# Altium schematic sheet ALimb.SchDoc(ALimb)
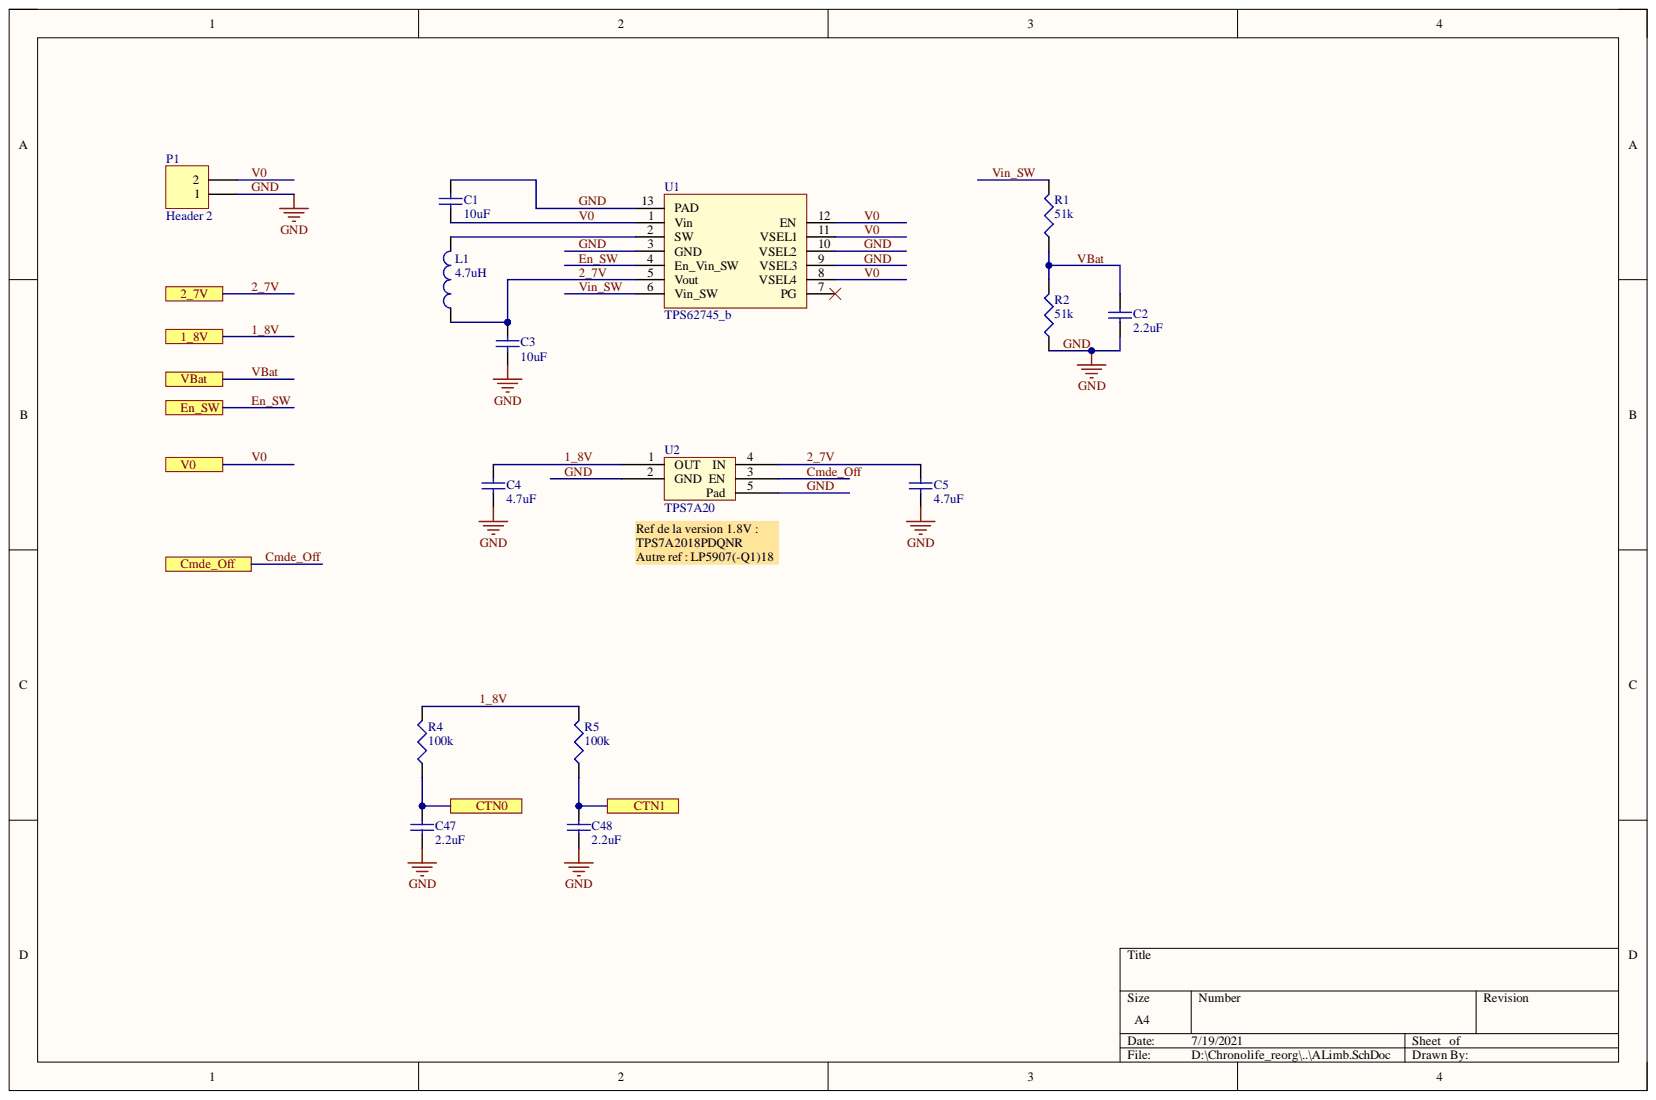
[diagram p0: ALimb.SchDoc]

## Parts
C1  10uF  [0603_GC]
C2  2.2uF  [0402_GC]
C3  10uF  [0603_GC]
C4  4.7uF  [0402_GC]
C5  4.7uF  [0402_GC]
C47  2.2uF  [0402_GC]
C48  2.2uF  [0402_GC]
L1  4.7uH  [SELF_252012]
P1  Header 2  [2SIP150PAD_G]
R1  51k  [0402_GC]
R2  51k  [0402_GC]
R4  100k  [0402_GC]
R5  100k  [0402_GC]
U1  TPS62745_b  [TPS62740]
U2  TPS7A20  [X2SON]

## Ports
1_8V, 2_7V, Cmde_Off, CTN0, CTN1, En_SW, V0, VBat

## Nets
1_8V: C4.2 R4.2 R5.2 U2.1(OUT) ; port 1_8V
2_7V: C3.1 C5.2 L1.1 U1.5(Vout) U2.4(IN) ; port 2_7V
Cmde_Off: U2.3(EN) ; port Cmde_Off
CTN0: C47.2 R4.1 ; port CTN0
CTN1: C48.2 R5.1 ; port CTN1
En_SW: U1.4(En_Vin_SW) ; port En_SW
GND: C1.1 C2.1 C3.2 C4.1 C5.1 C47.1 C48.1 P1.1 R2.1 U1.3(GND) U1.9(VSEL3) U1.10(VSEL2) U1.13(PAD) U2.2(GND) U2.5(Pad)
V0: C1.2 P1.2 U1.1(Vin) U1.8(VSEL4) U1.11(VSEL1) U1.12(EN) ; port V0
VBat: C2.2 R1.1 R2.2 ; port VBat
Vin_SW: R1.2 U1.6(Vin_SW)
NetL1_2: L1.2 U1.2(SW)

Not connected: U1 7(PG)

## Library data of the parts
C1-C5 C47 C48 = Cap: Capacitor; Component Kind: Standard; LatestRevisionDate: 17-Jul-2002; LatestRevisionNote: Re-released for DXP Platform.; Library Name: Miscellaneous Devices.IntLib; PackageDescription: Radial Cap, Thru-Hole; 2 Leads; 0.3 in Pin Spacing; PackageReference: RAD-0.3; Published: 8-Jun-2000; Signal Integrity: Cap; Simulation: CAP; Publisher: Altium Limited
  C1 C3: Value: 10uF
  C2 C47 C48: Value: 2.2uF
  C4 C5: Value: 4.7uF
L1 = Inductor: Code_IEC: 1005; Code_JEITA: 0402; Component Kind: Standard; Description: Inductor; LatestRevisionDate: 29-May-2009; LatestRevisionNote: IPC-7351 Footprint Added.; Library Name: Miscellaneous Devices.IntLib; PackageDescription: Chip Inductor; PackageReference: 0402-A; Published: 8-Jun-2000; Signal Integrity: Inductor; Simulation: INDUCTOR; Value: 4.7uH; Publisher: Altium Limited
P1 = Header 2: Header, 2-Pin; Component Kind: Standard; LatestRevisionDate: 17-Jul-2002; LatestRevisionNote: Re-released for DXP Platform.; Library Name: Miscellaneous Connectors.IntLib; Signal Integrity: Connector; Publisher: Altium Limited
R1 R2 R4 R5 = Res3: Resistor; Component Kind: Standard; LatestRevisionDate: 29-May-2009; LatestRevisionNote: IPC-7351 Footprint Added.; Library Name: Miscellaneous Devices.IntLib; PackageDescription: Chip Resistor; PackageReference: J1-0603; Published: 8-Jun-2000; Signal Integrity: Res; Simulation: RESISTOR; Publisher: Altium Limited
  R1 R2: Value: 51k
  R4 R5: Value: 100k
U1 = TPS62745_b: Component Kind: Standard; Library Name: Components_0.SchLib
U2 = TPS7A20: Component Kind: Standard; Library Name: Components_0.SchLib
